# Altium schematic sheet NiMH Battery Charger.SchDoc("NiMH Battery Charger")
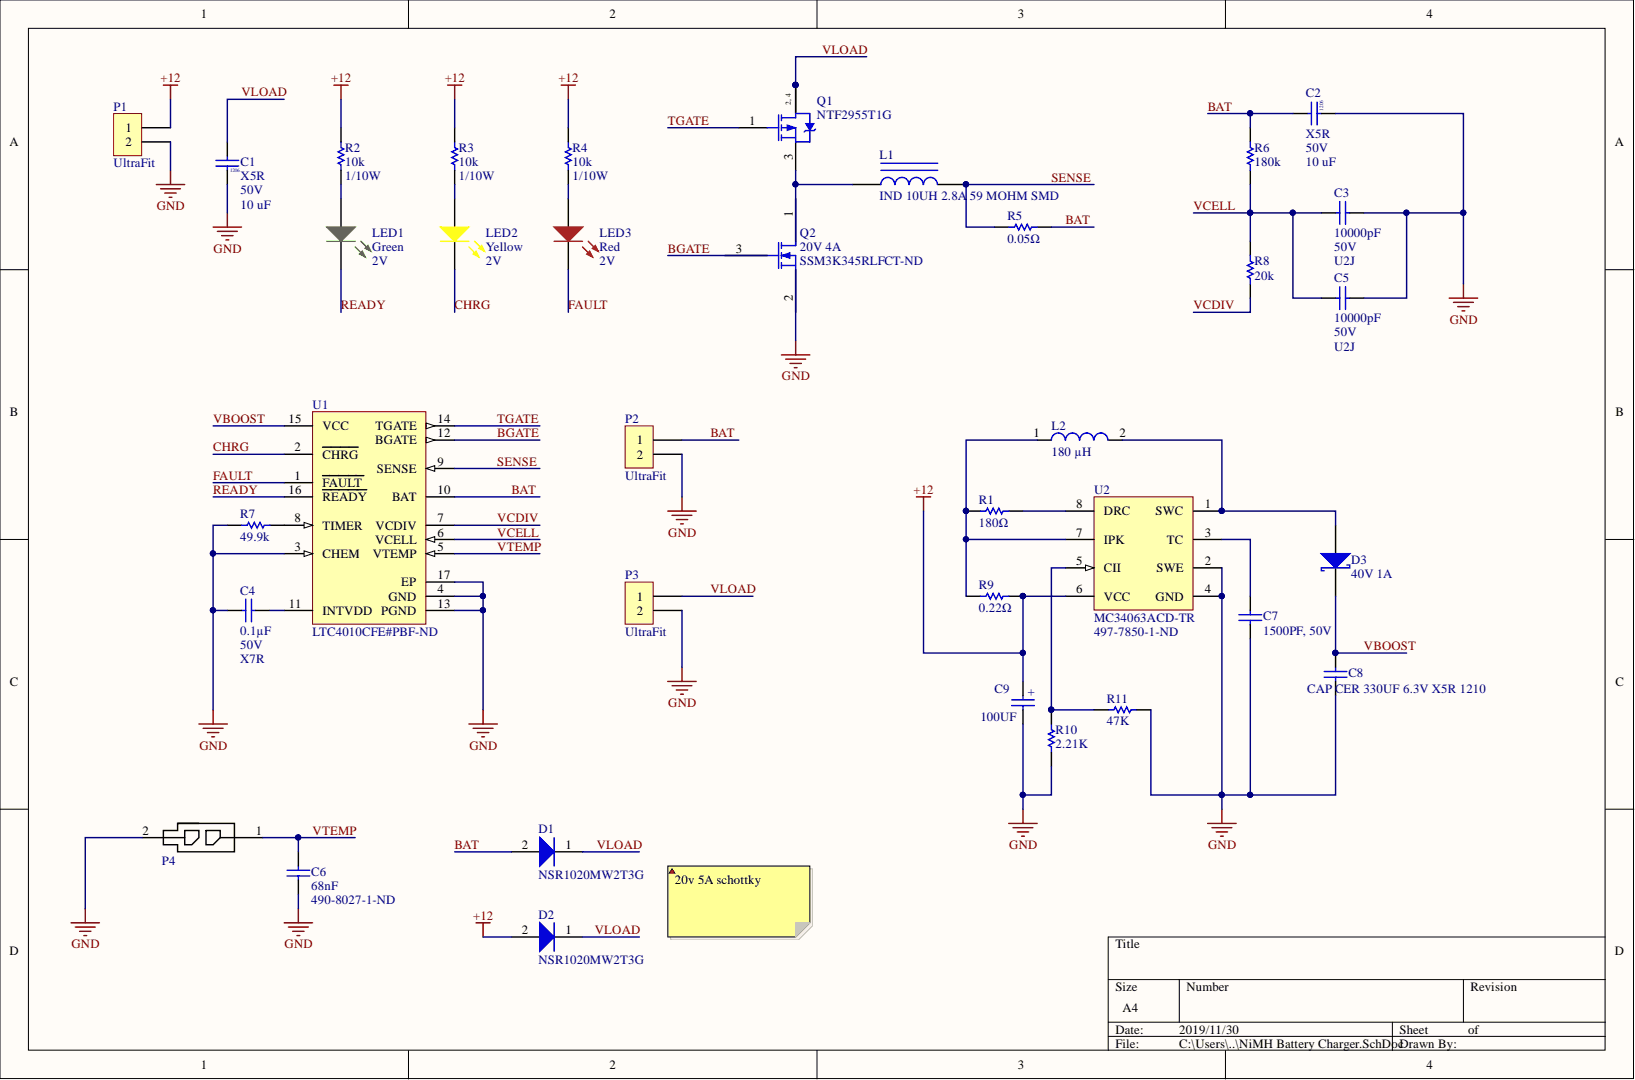
[diagram p0: NiMH Battery Charger.SchDoc]

## Parts
C1  Voltage Rating: 50V  Rating: X5R  Case Code (Imperial): 1206  Capacitance: 10 uF  [CAP, 1206]  (hidden comment: CAP CER 10uF 50V 20% X5R 1206)
C2  Voltage Rating: 50V  Rating: X5R  Case Code (Imperial): 1206  [CAP, 1206]  (hidden comment: CAP CER 10uF 50V 20% X5R 1206)
C3  Voltage: 50V  Capacitance: 10000pF  [CAP, 0603]  (hidden comment: CAP CER 10nF 50V 5% X7R 0603)
C4  Voltage: 50V  Capacitance: 0.1µF  [CAP, 0603]  (hidden comment: CAP CER 0.1UF 50V 10% X7R 0603)
C5  Voltage: 50V  Temperature Coefficient: U2J  Capacitance: 10000pF  [CAP, 0603]  (hidden comment: CAP CER 10nF 50V 5% X7R 0603)
C6  68nF  Supplier Part Number 1: 490-8027-1-ND  [CAP, 0603]
C7  1500PF, 50V  [CAP, 0603]
C8  CAP CER 330UF 6.3V X5R 1210  [CAP, 1210]
C9  100UF  [CAP ALUM 6.3MM RADIAL SMD]
D1  NSR1020MW2T3G  [ONSC-SOD-323-2-477-02_V]
D2  NSR1020MW2T3G  [ONSC-SOD-323-2-477-02_V]
D3  40V 1A  [MELF]
L1  IND 10UH 2.8A 59 MOHM SMD  Max DC Current: 2.8A  [INDP100102X31N]
L2  180 µH  [WE-PD-XL]
LED1  Voltage - Forward (Vf) (Typ): 2V  Color: Green  [LED_0603]  (hidden comment: LED GREEN CLEAR 2V 0603)
LED2  Voltage - Forward (Vf) (Typ): 2V  Color: Yellow  [LED_0603]  (hidden comment: LED YELLOW CLEAR 2.1V 0603)
LED3  Voltage - Forward (Vf) (Typ): 2V  Color: Red  [LED_0603]  (hidden comment: LED RED CLEAR 2V 0603)
P1  Series: UltraFit  [CONN, 2POS ULTRA-FIT]  (hidden comment: CONN 2POS ULTRA-FIT 0.138")
P2  Series: UltraFit  [CONN, 2POS ULTRA-FIT]  (hidden comment: CONN 2POS ULTRA-FIT 0.138")
P3  Series: UltraFit  [CONN, 2POS ULTRA-FIT]  (hidden comment: CONN 2POS ULTRA-FIT 0.138")
P4  CONN 2POS MICRO-FIT 3mm  [CONN, 2POS MICRO-FIT]  (value not drawn)
Q1  NTF2955T1G  [ONSC-SOT-223-4-318E-04_V]
Q2  20V 4A  Supplier Part Number 1: SSM3K345RLFCT-ND  [SOT23]
R1  180Ω  [RES, 0603]
R2  Resistance: 10k  Power: 1/10W  [RES, 0603]  (hidden comment: RES 10K OHM 1% 1/10W 0603)
R3  Resistance: 10k  Power: 1/10W  [RES, 0603]  (hidden comment: RES 10K OHM 1% 1/10W 0603)
R4  Resistance: 10k  Power: 1/10W  [RES, 0603]  (hidden comment: RES 10K OHM 1% 1/10W 0603)
R5  0.05Ω  [RES, 0603]
R6  180k  [RES, 0603]
R7  49.9k  [RES, 0603]
R8  20k  [RES, 0603]
R9  0.22Ω  [RES, 0603]
R10  2.21K  [RES, 0603]
R11  47K  [RES, 0603]
U1  Supplier Part Number 1: LTC4010CFE#PBF-ND  [LT-FE-16-EPV-BC_L]
U2  MC34063ACD-TR  Supplier Part Number 1: 497-7850-1-ND  [SO8_N]

## Nets
+12: C9.1 D2.2 P1.1 R2.1 R3.1 R4.1 R9.1 U2.6(VCC)
GND: C1.2 C2.2 C3.2 C4.2 C5.2 C6.2 C7.2 C8.2 C9.2 P1.2 P2.2 P3.2 P4.2 Q2.2 R7.1 R10.2 R11.2 U1.3(CHEM) U1.4(GND) U1.13(PGND) U1.17(EP) U2.2(SWE) U2.4(GND)
BAT: C2.1 D1.2 P2.1 R5.2 R6.2 U1.10(BAT)
BGATE: Q2.3 U1.12(BGATE)
CHRG: LED2.2 U1.2(CHRG)
FAULT: LED3.2 U1.1(FAULT)
READY: LED1.2 U1.16(READY)
SENSE: L1.2 R5.1 U1.9(SENSE)
TGATE: Q1.1 U1.14(TGATE)
VBOOST: C8.1 D3.2 U1.15(VCC)
VCDIV: R8.1 U1.7(VCDIV)
VCELL: C3.1 C5.1 R6.1 R8.2 U1.6(VCELL)
VLOAD: C1.1 D1.1 D2.1 P3.1 Q1.2 Q1.4
VTEMP: C6.1 P4.1 U1.5(VTEMP)
NetC4_1: C4.1 U1.11(INTVDD)
NetC7_1: C7.1 U2.3(TC)
NetD3_1: D3.1 L2.2 U2.1(SWC)
NetL1_1: L1.1 Q1.3 Q2.1
NetL2_1: L2.1 R1.2 R9.2 U2.7(IPK)
NetLED1_1: LED1.1 R2.2
NetLED2_1: LED2.1 R3.2
NetLED3_1: LED3.1 R4.2
NetR1_1: R1.1 U2.8(DRC)
NetR7_2: R7.2 U1.8(TIMER)
NetR10_1: R10.1 R11.1 U2.5(CII)

## Library data of the parts
C1 C2 = CAP CER 10uF 50V 20% X5R 1206: 10µF ±20% 50V Ceramic Capacitor X5R 1206 (3216 Metric); Manufacturer Part Number: GRT31CR61H106ME01L; Manufacturer: Murata; Width: 0.063inch; Tolerance: 20%; Thickness: 0.071inch; Supplier Part Number 1: 490-12457-1-ND; Supplier 1: Digi-Key; Stock 1: 449467; RoHS: Yes; Ratings: AEC-Q200; Pricing 1: 1 = 0.57000, 10 = 0.39800, 100 = 0.23910, 500 = 0.17930, 1000 = 0.15141 (USD); Packaging: Tape and Reel; Mount: Surface Mount; Min Operating Temperature: -55°C; Max Operating Temperature: 85°C; Length: 0.126inch; Component Kind: Standard; Case Code (Metric): 3216; Case/Package: 1206; Library Name: Schematic Diagrams.SchLib
  C2: Capacitance: 10 uF
C3 C5 = CAP CER 10nF 50V 5% X7R 0603: 10000pF ±5% 50V Ceramic Capacitor U2J 0603 (1608 Metric); Manufacturer Part Number 1: C0603C103J5JAC7867; Manufacturer 1: KEMET; Tolerance: ±5%; Thickness (Max): 0.034" (0.87mm); Supplier Part Number 1: 399-13384-1-ND; Supplier 1: Digi-Key; Size / Dimension: 0.063" L x 0.032" W (1.60mm x 0.80mm); Ratings: -; Part Status: Active; Packaging: Cut Tape (CT); Package / Case: 0603 (1608 Metric); Operating Temperature: -55°C ~ 125°C; Mounting Type: Surface Mount, MLCC; Lead Style: -; Lead Spacing: -; Height - Seated (Max): -; Failure Rate: -; ComponentLink1URL: http://www.kemet.com/docfinder?Partnumber=C0603C103J5JAC7867; ComponentLink1Description: http://www.kemet.com/docfinder?Partnumber=C0603C103J5JAC7867; Component Kind: Standard; Library Name: Schematic Diagrams.SchLib
  C3: Temperature Coefficient: U2J
C4 = CAP CER 0.1UF 50V 10% X7R 0603: 0.10µF ±10% 50V Ceramic Capacitor X7R 0603 (1608 Metric); Manufacturer Part Number 1: 06035C104KAT2A; Manufacturer 1: AVX Corporation; Tolerance: ±10%; Thickness (Max): 0.035" (0.90mm); Temperature Coefficient: X7R; Supplier Part Number 1: 478-5052-1-ND; Supplier 1: Digi-Key; Size / Dimension: 0.063" L x 0.032" W (1.60mm x 0.81mm); Ratings: -; Part Status: Active; Packaging: Cut Tape (CT); Package / Case: 0603 (1608 Metric); Operating Temperature: -55°C ~ 125°C; Mounting Type: Surface Mount, MLCC; Lead Style: -; Lead Spacing: -; Height - Seated (Max): -; ComponentLink1URL: http://datasheets.avx.com/X7RDielectric.pdf; ComponentLink1Description: http://datasheets.avx.com/X7RDielectric.pdf; Component Kind: Standard; Library Name: Schematic Diagrams.SchLib
C6 = CAP CER 0.068UF 10% 50V X7R 0603: 0.068µF 50V Ceramic Capacitor X7R 0603 (1608 Metric) 0.063" L x 0.032" W (1.60mm x 0.80mm); Manufacturer Part Number: GCM188R71H683KA57D; Manufacturer: Murata; Tolerance: 10%; Width: 0.031inch; Voltage Rating (DC): 50V; Voltage Rating: 50V; Thickness: 0.035inch; Termination: SMD/SMT; Supplier 1: Digi-Key; Stock 1: 40000; Radiation Hardening: No; Pricing 1: 1=0.16, 10=0.116, 100=0.0547, 500=0.03832, 1000=0.03172 (USD); Packaging: Tape and Reel; Package Quantity: 4000; Mount: Surface Mount; Min Operating Temperature: -55°C; Max Operating Temperature: 125°C; Length: 1.6mm; Height: 0.8mm; Dielectric: X7R; Depth: 0.8mm; ComponentLink3URL: https://datasheet.ciiva.com/29542/s0717e-29542429.pdf; ComponentLink3Description: https://datasheet.ciiva.com/29542/s0717e-29542429.pdf; ComponentLink2URL: https://datasheet.ciiva.com/29542/s0717e-29542428.pdf; ComponentLink2Description: https://datasheet.ciiva.com/29542/s0717e-29542428.pdf; ComponentLink1URL: https://datasheet.ciiva.com/29542/s0717e-29542427.pdf; ComponentLink1Description: https://datasheet.ciiva.com/29542/s0717e-29542427.pdf; Component Kind: Standard; Case Code (Metric): 1608; Case Code (Imperial): 0603; Case/Package: 0603; Capacitance: 68nF; Library Name: Schematic Diagrams.SchLib
C7 = CAP, 1500PF, 50V, 10%, X7R, 0603: KEMET - C0603C152K5RACTU - CAP, 1500PF, 50V, 10%, X7R, 0603; Dissipation Factor: 2.5%; Dielectric Material: Ceramic; Capacitance: 1.5nF; Width: 0.8mm; Voltage Rating (DC): 50V; Voltage Rating: 50V; Voltage: 50V; Tolerance: 10%; Thickness: 0.034inch; Termination: SMD/SMT; Supplier 1: Digi-Key; Stock 1: 207623; RoHS: Yes; REACH SVHC: No SVHC; Radiation Hardening: No; Pricing 1: 1=0.10000, 10=0.05000, 100=0.02230, 500=0.01594, 1000=0.01252 (USD); Packaging: Tape and Reel; Package Quantity: 1; Number of Pins: 2; Mount: Surface Mount,PCB; Min Operating Temperature: -55°C; Max Operating Temperature: 125°C; Manufacturer Part Number 1: C0603C152K5RACTU; Manufacturer 1: KEMET; Length: 1.6mm; Lead Pitch: 0.7mm; Height: 0.8mm; Dielectric: X7R; Depth: 0.8mm; Contact Plating: Tin,Matte; ComponentLink7URL: https://datasheet.ciiva.com/14597/70095383-14597806.pdf?src-supplier=Allied+Electronics; ComponentLink7Description: https://datasheet.ciiva.com/14597/70095383-14597806.pdf?src-supplier=Allied+Electronics; ComponentLink6URL: https://datasheet.ciiva.com/6177/kem-c1002-x7r-smd-6177916.pdf?src-supplier=Farnell; ComponentLink6Description: https://datasheet.ciiva.com/6177/kem-c1002-x7r-smd-6177916.pdf?src-supplier=Farnell; ComponentLink5URL: https://datasheet.ciiva.com/7823/40889-7823643.pdf?src-supplier=Element14; ComponentLink5Description: https://datasheet.ciiva.com/7823/40889-7823643.pdf?src-supplier=Element14; ComponentLink4URL: https://datasheet.ciiva.com/18192/getdatasheetpartid-104358-18192810.pdf?src-supplier=Verical; ComponentLink4Description: https://datasheet.ciiva.com/18192/getdatasheetpartid-104358-18192810.pdf?src-supplier=Verical; ComponentLink3URL: https://datasheet.ciiva.com/7823/40889-7823642.pdf?src-supplier=Element14; ComponentLink3Description: https://datasheet.ciiva.com/7823/40889-7823642.pdf?src-supplier=Element14; ComponentLink2URL: https://datasheet.ciiva.com/28645/1851736-28645673.pdf?src-supplier=Element14; ComponentLink2Description: https://datasheet.ciiva.com/28645/1851736-28645673.pdf?src-supplier=Element14; ComponentLink1URL: https://datasheet.ciiva.com/7824/kem-c1002-x7r-smd-7824006.pdf?src-supplier=Element14; ComponentLink1Description: https://datasheet.ciiva.com/7824/kem-c1002-x7r-smd-7824006.pdf?src-supplier=Element14; Component Kind: Standard; Case Code (Metric): 1608; Case Code (Imperial): 0603; Case/Package: 0603; Library Name: Schematic Diagrams.SchLib
C8 = CAP CER 330UF 6.3V X5R 1210: 330µF ±20% 6.3V Ceramic Capacitor X5R 1210 (3225 Metric); Manufacturer Part Number: JMK325ABJ337MM-P; Manufacturer: Taiyo Yuden; Supplier Part Number 1: 587-5449-1-ND; Supplier 1: Digi-Key; Stock 1: 1427; Pricing 1: 1=5.57, 10=4.492, 100=3.5039, 500=2.6055 (USD); Component Kind: Standard; Library Name: Schematic Diagrams.SchLib
C9 = CAP ALUM 100UF 20% 35V SMD: 100µF 35V Aluminum Electrolytic Capacitors Radial, Can - SMD 2000 Hrs @ 85°C; Manufacturer Part Number 1: EEE-1VA101XP; Manufacturer 1: Panasonic; Tolerance: 20%; Ripple Current (AC): 132mA; Polarity: Polar; Life (Hours): 2000Hour; Leakage Current: 3uA; Description 1: Cap Aluminum Lytic 100uF 35V 20% (6.3 X 7.7mm) SMD 132mA 2000h 85C Automotive T/R; Voltage Rating (DC): 35V; Voltage Rating: 35V; Voltage: 35V; Termination: SMD/SMT; Supplier Part Number 1: PCE3951CT-ND; Supplier 1: Digi-Key; Stock 1: 170823; Ripple Current: 132mA; REACH SVHC: No SVHC; Radiation Hardening: No; Pricing 1: 1=0.44, 10=0.304, 100=0.1822 (USD); Packaging: Tape and Reel; Package Quantity: 900; Number of Pins: 8; Mount: Surface Mount,PCB; Min Operating Temperature: -40°C; Max Operating Temperature: 85°C; Length: 8mm; Lead Free: Lead Free; Height - Seated (Max): 0.303inch; Height: 7.7mm; Diameter: 6.3mm; Contact Plating: Tin; ComponentLink9URL: https://datasheet.ciiva.com/11673/aba0000ce2-11673887.pdf; ComponentLink9Description: https://datasheet.ciiva.com/11673/aba0000ce2-11673887.pdf; ComponentLink8URL: https://datasheet.ciiva.com/46374/aba0000ce2-46374427.pdf; ComponentLink8Description: https://datasheet.ciiva.com/46374/aba0000ce2-46374427.pdf; ComponentLink7URL: https://datasheet.ciiva.com/14600/70255710-14600731.pdf; ComponentLink7Description: https://datasheet.ciiva.com/14600/70255710-14600731.pdf; ComponentLink6URL: https://datasheet.ciiva.com/14591/70255710-14591771.pdf; ComponentLink6Description: https://datasheet.ciiva.com/14591/70255710-14591771.pdf; ComponentLink5URL: https://datasheet.ciiva.com/24068/aba0000ce2-40801-24068089.pdf; ComponentLink5Description: https://datasheet.ciiva.com/24068/aba0000ce2-40801-24068089.pdf; ComponentLink4URL: https://datasheet.ciiva.com/31414/aba0000ce2-31414696.pdf; ComponentLink4Description: https://datasheet.ciiva.com/31414/aba0000ce2-31414696.pdf; ComponentLink3URL: https://datasheet.ciiva.com/30170/11aba0000ce2-30170103.pdf; ComponentLink3Description: https://datasheet.ciiva.com/30170/11aba0000ce2-30170103.pdf; ComponentLink2URL: https://datasheet.ciiva.com/17535/aba0000ce2-17535510.pdf; ComponentLink2Description: https://datasheet.ciiva.com/17535/aba0000ce2-17535510.pdf; ComponentLink1URL: https://datasheet.ciiva.com/46378/aba0000ce2-46378341.pdf; ComponentLink1Description: https://datasheet.ciiva.com/46378/aba0000ce2-46378341.pdf; Component Kind: Standard; Case/Package: Radial; Capacitance: 100uF; Library Name: Schematic Diagrams.SchLib
D1 D2 = DIODE Schottky Barrier: Schottky Barrier Diode, 2-Pin SOD-323, Pb-Free, Tape and Reel; Manufacturer: On Semiconductor; IFSM Max (A): 5; Configuration: Single; Supplier 1: Digi-Key; RoHS: TRUE; PartNumber: NSR1020MW2T3G; Packing: Tape and Reel; PackageVersion: Rev. H, 03/2007; PackageReference: SOD-323-2-477-02; PackageDescription: 2-Pin SOD, Body 1.7 x 1.25 mm; Mounting Technology: Surface Mount; DatasheetVersion: Rev. 1; ComponentLink3URL: http://www.onsemi.com/pub_link/Collateral/477-02.PDF.1; ComponentLink3Description: Package Specification; ComponentLink2URL: http://www.onsemi.cn/pub_link/Collateral/NSR1020MW2T1-D.PDF; ComponentLink2Description: Datasheet; ComponentLink1URL: http://www.onsemi.com/; ComponentLink1Description: Manufacturer URL; Component Kind: Standard; Library Name: Schematic Diagrams.SchLib
D3 = DIODE SCHOTTKY 40V 1A MELF: Diode Schottky 40V 1A Surface Mount MELF; Component Kind: Standard; Library Name: Schematic Diagrams.SchLib
L1 = IND 10UH 2.8A 59 MOHM SMD: 10µH Shielded Inductor 2.8A 59 mOhm Max Nonstandard; Manufacturer Part Number: CDRH103RNP-100NC-B; Manufacturer: Sumida; Tolerance: 30%; Test Frequency: 100kHz; Saturation Current: 3.18A; DC Resistance (DCR): 59mR; Core Material: Ferrite; Width: 0.394inch; Termination: SMD/SMT; Supplier Part Number 1: 308-1910-1-ND; Supplier 1: Digi-Key; Stock 1: 69; Shielding: Shielded; Radiation Hardening: No; Pricing 1: 1=1.53, 10=1.42, 100=1.027, 500=0.7866 (USD); Packaging: Tape and Reel; Package Quantity: 1000; Mount: Surface Mount; Min Operating Temperature: -40°C; Max Operating Temperature: 100°C; Length: 0.402inch; Lead Free: Lead Free; Inductance: 10uh; Height - Seated (Max): 0.122inch; Height: 3.1mm; Depth: 10.3mm; Current Rating: 2.8A; ComponentLink3URL: http://products.sumida.com/products/pdf/CDRH103R.pdf; ComponentLink3Description: http://products.sumida.com/products/pdf/CDRH103R.pdf; ComponentLink2URL: http://www.sumida.com/products/pdf/CDRH103R.pdf; ComponentLink2Description: http://www.sumida.com/products/pdf/CDRH103R.pdf; ComponentLink1URL: http://www.mouser.com/ds/2/390/CDRH103R-523372.pdf; ComponentLink1Description: http://www.mouser.com/ds/2/390/CDRH103R-523372.pdf; Component Kind: Standard; Case/Package: Nonstandard; Library Name: Schematic Diagrams.SchLib
L2 = IND 180 µH Power Inductor: SMD-Shielded Power Inductor WE-PD, L = 180 µH; Inductance: 180 ÂµH; PackageVersion: 2004; PackageReference: WE-PD-XL; PackageDescription: SMD Inductor, 2-Leads, Body 12.0 x 12.0 mm; DatasheetVersion: ver 5, Oct-2004; ComponentLink2URL: http://www.we-online.de/katalog/media/pdf/744770218.pdf; ComponentLink2Description: Datasheet; ComponentLink1URL: http://www.we-online.com; ComponentLink1Description: Manufacturer Link; Component Kind: Standard; Library Name: Schematic Diagrams.SchLib
LED1 = LED GREEN CLEAR 2V 0603: Green 572nm LED Indication - Discrete 2V 0603 (1608 Metric); Manufacturer Part Number: 150060VS75000; Manufacturer: Wurth Electronics Inc.; Wavelength - Dominant: 570nm; Viewing Angle: 140°; Millicandela Rating: 40mcd; Lens Style/Size: Rectangle with Flat Top, 1.10mm x 0.70mm; Lens Color: Colorless; Current - Test: 20mA; Wavelength - Peak: 572nm; Supplier Part Number 1: 732-4980-1-ND; Supplier Device Package: 0603; Supplier 1: Digi-Key; Stock 1: 9408; Size / Dimension: 1.60mm L x 0.80mm W; RoHS: RoHS Compliant; Pricing 1: 1=0.21, 100=0.1617, 500=0.13964 (CAD); Part Status: Active; Packaging: Cut Tape (CT); Package / Case: 0603 (1608 Metric); Mounting Type: Surface Mount; Lens Transparency: Clear; Height (Max): 0.80mm; Features: -; ComponentLink1URL: http://katalog.we-online.de/led/datasheet/150060VS75000.pdf; ComponentLink1Description: http://katalog.we-online.de/led/datasheet/150060VS75000.pdf; Component Kind: Standard; Category: Optoelectronics; Library Name: Schematic Diagrams.SchLib
LED2 = LED YELLOW CLEAR 2.1V 0603: Yellow 590nm LED Indication - Discrete 2V 0603 (1608 Metric); Manufacturer Part Number: 150060YS75000; Manufacturer: Wurth Electronics Inc.; Wavelength - Peak: 595nm; Viewing Angle: 140°; Millicandela Rating: 120mcd; Lens Style/Size: Rectangle with Flat Top, 1.10mm x 0.70mm; Lens Color: Colorless; Current - Test: 20mA; Wavelength - Dominant: 590nm; Supplier Part Number 1: 732-4981-1-ND; Supplier Device Package: 0603; Supplier 1: Digi-Key; Stock 1: 10523; Size / Dimension: 1.60mm L x 0.80mm W; RoHS: RoHS Compliant; Pricing 1: 1=0.21, 100=0.1617, 500=0.13964 (CAD); Part Status: Active; Packaging: Cut Tape (CT); Package / Case: 0603 (1608 Metric); Mounting Type: Surface Mount; Lens Transparency: Clear; Height (Max): 0.80mm; Features: -; ComponentLink1URL: http://katalog.we-online.de/led/datasheet/150060YS75000.pdf; ComponentLink1Description: http://katalog.we-online.de/led/datasheet/150060YS75000.pdf; Component Kind: Standard; Category: Optoelectronics; Library Name: Schematic Diagrams.SchLib
LED3 = LED RED CLEAR 2V 0603: Red 631nm LED Indication - Discrete 2V 0603 (1608 Metric); Manufacturer Part Number: 150060RS75000; Manufacturer: Wurth Electronics Inc.; Wavelength - Peak: 630nm; Wavelength - Dominant: 625nm; Viewing Angle: 140°; Millicandela Rating: 250mcd; Lens Style/Size: Rectangle with Flat Top, 1.10mm x 0.70mm; Lens Color: Colorless; Current - Test: 20mA; Supplier Part Number 1: 732-4978-1-ND; Supplier Device Package: 0603; Supplier 1: Digi-Key; Stock 1: 35521; Size / Dimension: 1.60mm L x 0.80mm W; RoHS: RoHS Compliant; Pricing 1: 1=0.21, 100=0.1617, 500=0.13964 (CAD); Part Status: Active; Packaging: Cut Tape (CT); Package / Case: 0603 (1608 Metric); Mounting Type: Surface Mount; Lens Transparency: Clear; Height (Max): 0.80mm; Features: -; ComponentLink1URL: http://katalog.we-online.de/led/datasheet/150060RS75000.pdf; ComponentLink1Description: http://katalog.we-online.de/led/datasheet/150060RS75000.pdf; Component Kind: Standard; Category: Optoelectronics; Library Name: Schematic Diagrams.SchLib
P1-P3 = CONN 2POS ULTRA-FIT 0.138": Connector Header Through Hole 2 position 0.138" (3.50mm); Manufacturer Part Number: 1722871102; Manufacturer: Molex; Pitch: 0.138inch; Number of Positions: 2; Connector Type: Male Pin; Termination: Solder; Supplier Part Number 1: WM11701-ND; Supplier 1: Digi-Key; Stock 1: 2743; RoHS: Yes; Pricing 1: 1 = 0.78000, 10 = 0.68800, 100 = 0.59380, 500 = 0.48582, 1000 = 0.43184, 5000 = 0.37786, 10000 = 0.35897 (USD); Packaging: Tray; Number of Rows: 1; Mount: Through Hole; Fastening Type: Latch; Contact Plating: Tin; ComponentLink1URL: http://www.molex.com/pdm_docs/sd/1722861302_sd.pdf; ComponentLink1Description: http://www.molex.com/pdm_docs/sd/1722861302_sd.pdf; Component Kind: Standard; Color: Black; Library Name: Schematic Diagrams.SchLib
P4 = CONN 2POS MICRO-FIT 3mm: Connector Header Through Hole 2 position; Manufacturer Part Number: 43045-0227; Manufacturer: Molex; Voltage Rating: 600V; Number of Positions: 2; Number of Ports: 1; Max Voltage Rating (DC): 250.25kV; Glow Wire Compliant: Compliant; Gender: Male; Current Rating: 5A; Contact Resistance: 10mR; Termination: Solder; Supplier Part Number 1: WM10657-ND; Supplier 1: Digi-Key; Stock 1: 3657; Row Spacing: 3mm; Radiation Hardening: No; Pricing 1: 1=0.84, 10=0.74, 25=0.6968, 50=0.6676, 100=0.6387, 250=0.5806, 500=0.52254, 1000=0.46448, 2500=0.42094 (USD); Pitch: 3mm; Packaging: Tray; Package Quantity: 3168; Orientation: Vertical; Number of Terminals: 2; Number of Rows: 2; Number of Contacts: 2; Number of Circuits: 2; Mount: Through Hole; Min Operating Temperature: -40°C; Max Operating Temperature: 105°C; Length: 7.15mm; Housing Material: Thermoplastic; Housing Colour: Black; Halogen Free: Low Halogen; Depth: 8.77mm; Contact Plating: Tin; Contact Material: Brass; ComponentLink4URL: http://www.molex.com/webdocs/datasheets/pdf/en-us/0430450227_PCB_HEADERS.pdf; ComponentLink4Description: http://www.molex.com/webdocs/datasheets/pdf/en-us/0430450227_PCB_HEADERS.pdf; ComponentLink3URL: http://uk.rs-online.com/webdocs/13c3/0900766b813c3a46.pdf; ComponentLink3Description: http://uk.rs-online.com/webdocs/13c3/0900766b813c3a46.pdf; ComponentLink2URL: http://www.mouser.com/ds/2/276/0430450227_PCB_HEADERS-142611.pdf; ComponentLink2Description: http://www.mouser.com/ds/2/276/0430450227_PCB_HEADERS-142611.pdf; ComponentLink1URL: http://www.onlinecomponents.com/productFiles/mf-MOL/307170430450227_pcb_headers.pdf; ComponentLink1Description: http://www.onlinecomponents.com/productFiles/mf-MOL/307170430450227_pcb_headers.pdf; Component Kind: Standard; Library Name: Schematic Diagrams.SchLib
Q1 = ONSC-PMOS-G1D2S3D4-4: Power MOSFET, -60 V, -2.6 A, Single P-Channel, 4-Pin SOT-223, Pb-Free, Tape and Reel; Manufacturer: On Semiconductor; VGS Max (V): 20; VGS(th) Max (V): 4; V(BR)DSS Min (V): 60; Qrr Typ (nC): 0.139; ID Max (A): 2.6; Crss Typ (pF): 50; Coss Typ (pF): 165; Ciss Typ (pF): 492; Channel Polarity: P Channel; RoHS: Pb-Free; PartNumber: NTF2955T1G; Packing: Tape and Reel; PackageVersion: Rev. N, 08/2009; Package Type: SOT-223-4 / TO-261-4; PackageReference: SOT-223-4-318E-04; PackageDescription: 4-Pin Small Outline Transistor, Body 6.5 x 3.5 mm, Pitch 2.3 mm; Mounting Technology: Surface Mount; DatasheetVersion: Rev. 6; Configuration: Single; ComponentLink3URL: http://www.onsemi.com/pub_link/Collateral/318E-04.PDF; ComponentLink3Description: Package Specification; ComponentLink2URL: http://www.onsemi.com/pub_link/Collateral/NTF2955-D.PDF; ComponentLink2Description: Datasheet; ComponentLink1URL: http://www.onsemi.com/; ComponentLink1Description: Manufacturer URL; Component Kind: Standard; Library Name: Schematic Diagrams.SchLib
Q2 = MOSFET N-CHANNEL 20V 4A SOT23F: N-Channel 20V 4A (Ta) 1W (Ta) Surface Mount SOT-23F; Supplier 1: Digi-Key; ComponentLink1URL: https://toshiba.semicon-storage.com/info/docget.jsp?did=55842&prodName=SSM3K345R; ComponentLink1Description: datasheet; Component Kind: Standard; Library Name: Schematic Diagrams.SchLib
R1 R9 = RES 180k Ohms: Part Number: CRCW0402180KFKED; Manufacturer: Vishay Dale; Supplier 1: Digi-Key; Component Kind: Standard; Library Name: Schematic Diagrams.SchLib
R2-R4 = RES 10K OHM 1% 1/10W 0603: RES SMD 10K OHM 1% 1/10W 0603; Manufacturer Part Number 1: RC0603FR-0710KL; Manufacturer 1: Yageo; Temperature Coefficient: ±100ppm/°C; Tolerance: ±1%; Supplier Part Number 1: 311-10.0KHRCT-ND; Supplier Device Package: 0603; Supplier 1: Digi-Key; Size / Dimension: 0.063" L x 0.031" W (1.60mm x 0.80mm); Part Status: Active; Packaging: Cut Tape (CT); Package / Case: 0603 (1608 Metric); Operating Temperature: -55°C ~ 155°C; Height - Seated (Max): 0.022" (0.55mm); Failure Rate: -; Composition: Thick Film; ComponentLink1URL: http://www.yageo.com/documents/recent/PYu-RC_Group_51_RoHS_L_7.pdf; ComponentLink1Description: http://www.yageo.com/documents/recent/PYu-RC_Group_51_RoHS_L_7.pdf; Component Kind: Standard; Library Name: Schematic Diagrams.SchLib
R5 = RES-2: Chip Resistor, 50 mOhm, +/- 1%, 1 W, -65 to 170 degC, 2512 (6432 Metric), RoHS, Tape and Reel; Manufacturer: Vishay; Temperature Coefficient: ±75ppm/°C; SVHC: NoSVHC(15-Jun-2015); Resistor Element Type: MetalStrip; Resistor Case Style: 2512[6432Metric]; Resistance: 0.05ohm; Product Range: WSLSeries; Power Rating: 1W; Automotive Qualification Standard: AEC-Q200; Resistance Tolerance: ±1%; Packaging: CutTape; Package Reference: 2512; Package Description: 2-Pin Surface Mount Device, Body 6.35 x 3.18 mm; MSL: MSL1-Unlimited; Mounting Technology: SurfaceMount; Manufacturer URL: http://www.vishay.com/; Datasheet Version: 07/2016; Datasheet URL: http://www.vishay.com/docs/30100/wsl.pdf; Component Kind: Standard; Library Name: Schematic Diagrams.SchLib
R6 = RES: Part Number: CRCW0402180KFKED; Manufacturer: Vishay Dale; Component Kind: Standard; Library Name: Schematic Diagrams.SchLib
R7 = CMP-2002-05244-1: Part Number: CR0402-FX-4992GLF; Manufacturer: Bourns; Library Name: Miscellaneous Devices.IntLib; Component Kind: Standard
R8 = RES: Part Number: CRCW040220K0FKED; Manufacturer: Vishay Dale; Component Kind: Standard; Library Name: Schematic Diagrams.SchLib
R10 = RES 2.21K OHM 1% 1/10W 0603: 2.21 kOhms ±1% 0.1W, 1/10W Chip Resistor 0603 (1608 Metric) Automotive AEC-Q200, Moisture Resistant Thick Film; Manufacturer Part Number: AC0603FR-072K21L; Manufacturer: Yageo; Working Voltage: 75V; Voltage Rating: 50V; Temperature Coefficient: 100ppm/°C; Resistance: 2.21kR; Power Rating: 100mW; Width: 0.8mm; Weight: 7.1E-05oz; Tolerance: 1%; Termination: SMD/SMT; Supplier Part Number 1: YAG3586CT-ND; Supplier 1: Digi-Key; Stock 1: 11020; Pricing 1: 1=0.1, 10=0.029, 100=0.0117, 1000=0.00527, 2500=0.00458 (USD); Packaging: Tape and Reel; Number of Terminations: 2; Min Operating Temperature: -55°C; Max Power Dissipation: 100mW; Max Operating Temperature: 155°C; Length: 0.063inch; Lead Free: Lead Free; Height: 0.022inch; Composition: Thick Film; ComponentLink1URL: https://datasheet.ciiva.com/12576/pyu-ac-51-rohs-l-2-12576458.pdf; ComponentLink1Description: https://datasheet.ciiva.com/12576/pyu-ac-51-rohs-l-2-12576458.pdf; Component Kind: Standard; Case Code (Metric): 1608; Case Code (Imperial): 0603; Case/Package: 0603; Library Name: Schematic Diagrams.SchLib
R11 = RES 47K OHM 1% 1/10W 0603: RES SMD 47K OHM 1% 1/10W 0603; Manufacturer Part Number 1: CRCW060347K0FKEA; Manufacturer 1: Vishay; Voltage Rating (DC): 75V; Temperature Coefficient: 100ppm/°C; Resistance: 47kR; Power Rating: 100mW; Width: 0.85mm; Weight: 7.1E-05oz; Voltage Rating: 75V; Tolerance: 1%; Termination: SMD/SMT; Supplier 1: Digi-Key; Stock 1: 303029; RoHS: Yes; REACH SVHC: Unknown; Radiation Hardening: No; Pricing 1: 1=0.10000, 10=0.03600, 100=0.01460, 1000=0.00654, 2500=0.00568 (USD); Packaging: Tape and Reel; Package Quantity: 5000; Mount: Surface Mount; Min Operating Temperature: -55°C; Military Standard: Not; Max Operating Temperature: 155°C; Length: 1.55mm; Lead Free: Lead Free; Height: 0.45mm; Depth: 0.85mm; Contact Plating: Tin; Composition: Thick Film; ComponentLink8URL: https://datasheet.ciiva.com/16286/dcrcwe3-16286447.pdf?src-supplier=Future+Electronics; ComponentLink8Description: https://datasheet.ciiva.com/16286/dcrcwe3-16286447.pdf?src-supplier=Future+Electronics; ComponentLink7URL: https://datasheet.ciiva.com/3257/dcrcwe3-3257631.pdf?src-supplier=Avnet; ComponentLink7Description: https://datasheet.ciiva.com/3257/dcrcwe3-3257631.pdf?src-supplier=Avnet; ComponentLink6URL: https://datasheet.ciiva.com/7777/125364-7777562.pdf?src-supplier=Element14; ComponentLink6Description: https://datasheet.ciiva.com/7777/125364-7777562.pdf?src-supplier=Element14; ComponentLink5URL: https://datasheet.ciiva.com/7777/1720486-7777607.pdf?src-supplier=Element14; ComponentLink5Description: https://datasheet.ciiva.com/7777/1720486-7777607.pdf?src-supplier=Element14; ComponentLink4URL: https://datasheet.ciiva.com/5548/dcrcwe3-109170-5548727.pdf?src-supplier=Mouser; ComponentLink4Description: https://datasheet.ciiva.com/5548/dcrcwe3-109170-5548727.pdf?src-supplier=Mouser; ComponentLink3URL: https://datasheet.ciiva.com/16893/dcrcwe3-16893430.pdf?src-supplier=Avnet+Europe; ComponentLink3Description: https://datasheet.ciiva.com/16893/dcrcwe3-16893430.pdf?src-supplier=Avnet+Europe; ComponentLink2URL: https://datasheet.ciiva.com/16763/70201119-16763141.pdf?src-supplier=Allied+Electronics; ComponentLink2Description: https://datasheet.ciiva.com/16763/70201119-16763141.pdf?src-supplier=Allied+Electronics; ComponentLink1URL: https://datasheet.ciiva.com/11808/0900766b80d98afc-11808694.pdf?src-supplier=RSComponents; ComponentLink1Description: https://datasheet.ciiva.com/11808/0900766b80d98afc-11808694.pdf?src-supplier=RSComponents; Component Kind: Standard; Case Code (Metric): 1608; Case Code (Imperial): 0603; Case/Package: 0603; Library Name: Schematic Diagrams.SchLib
U1 = IC Nickel Battery Charger: High Efficiency Standalone Nickel Battery Charger, 4.5 to 34 V Vin, 16-pin SOP (FE-16-EPV-BC), 0 to 85 degC, Pb-Free; PartNumber: LTC4010CFE#PBF; Manufacturer: Linear Technology; Vin Min(V): 4.5; Vin Max(V): 34; Type of Charger: Switching; Number of Cells: 1 to 16; Max Charge Current(A): 4; Float Voltage: Adj; Battery Chemistry: NiCd, NiMH; Supplier 1: Digi-Key; RoHS: TRUE; PackageVersion: Rev. J, 11/2012; PackageReference: FE-16; PackageDescription: 16-Pin Plastic TSSOP, Body 5 x 4.4 mm, Pitch 0.65 mm; Mounting Technology: Surface Mount; DatasheetVersion: Rev. B; ComponentLink3URL: http://cds.linear.com/docs/en/packaging/05081663__J_FE16(BC).pdf; ComponentLink3Description: Package Specification; ComponentLink2URL: http://cds.linear.com/docs/en/datasheet/4010fb.pdf; ComponentLink2Description: Datasheet; ComponentLink1URL: http://www.linear.com/; ComponentLink1Description: Manufacturer URL; Component Kind: Standard; Library Name: Schematic Diagrams.SchLib
U2 = IC Buck boost converter MC34063: DC/DC converter control circuit, 8-Pin SO, Tape and Reel; Supplier 1: Digi-Key; PackageVersion: Dec-2002; PackageReference: SO8; PackageDescription: 8-Pin Small Outline Integrated Circuit 1.27 mm Pitch; DatasheetVersion: Nov-2007; ComponentLink3URL: http://www.st.com/internet/com/TECHNICAL_RESOURCES/TECHNICAL_LITERATURE/PACKAGE_INFORMATION/CD00004680.pdf; ComponentLink3Description: Package Specification; ComponentLink2URL: http://www.st.com/internet/com/TECHNICAL_RESOURCES/TECHNICAL_LITERATURE/DATASHEET/CD00001232.pdf; ComponentLink2Description: Datasheet; ComponentLink1URL: http://www.st.com; ComponentLink1Description: Manufacturer URL; Component Kind: Standard; Code_JEDEC: MS-012-AA; Code_IPC: SOIC127P600-8; Library Name: Schematic Diagrams.SchLib
